# Altium schematic sheet Connector.SchDoc(Connector)
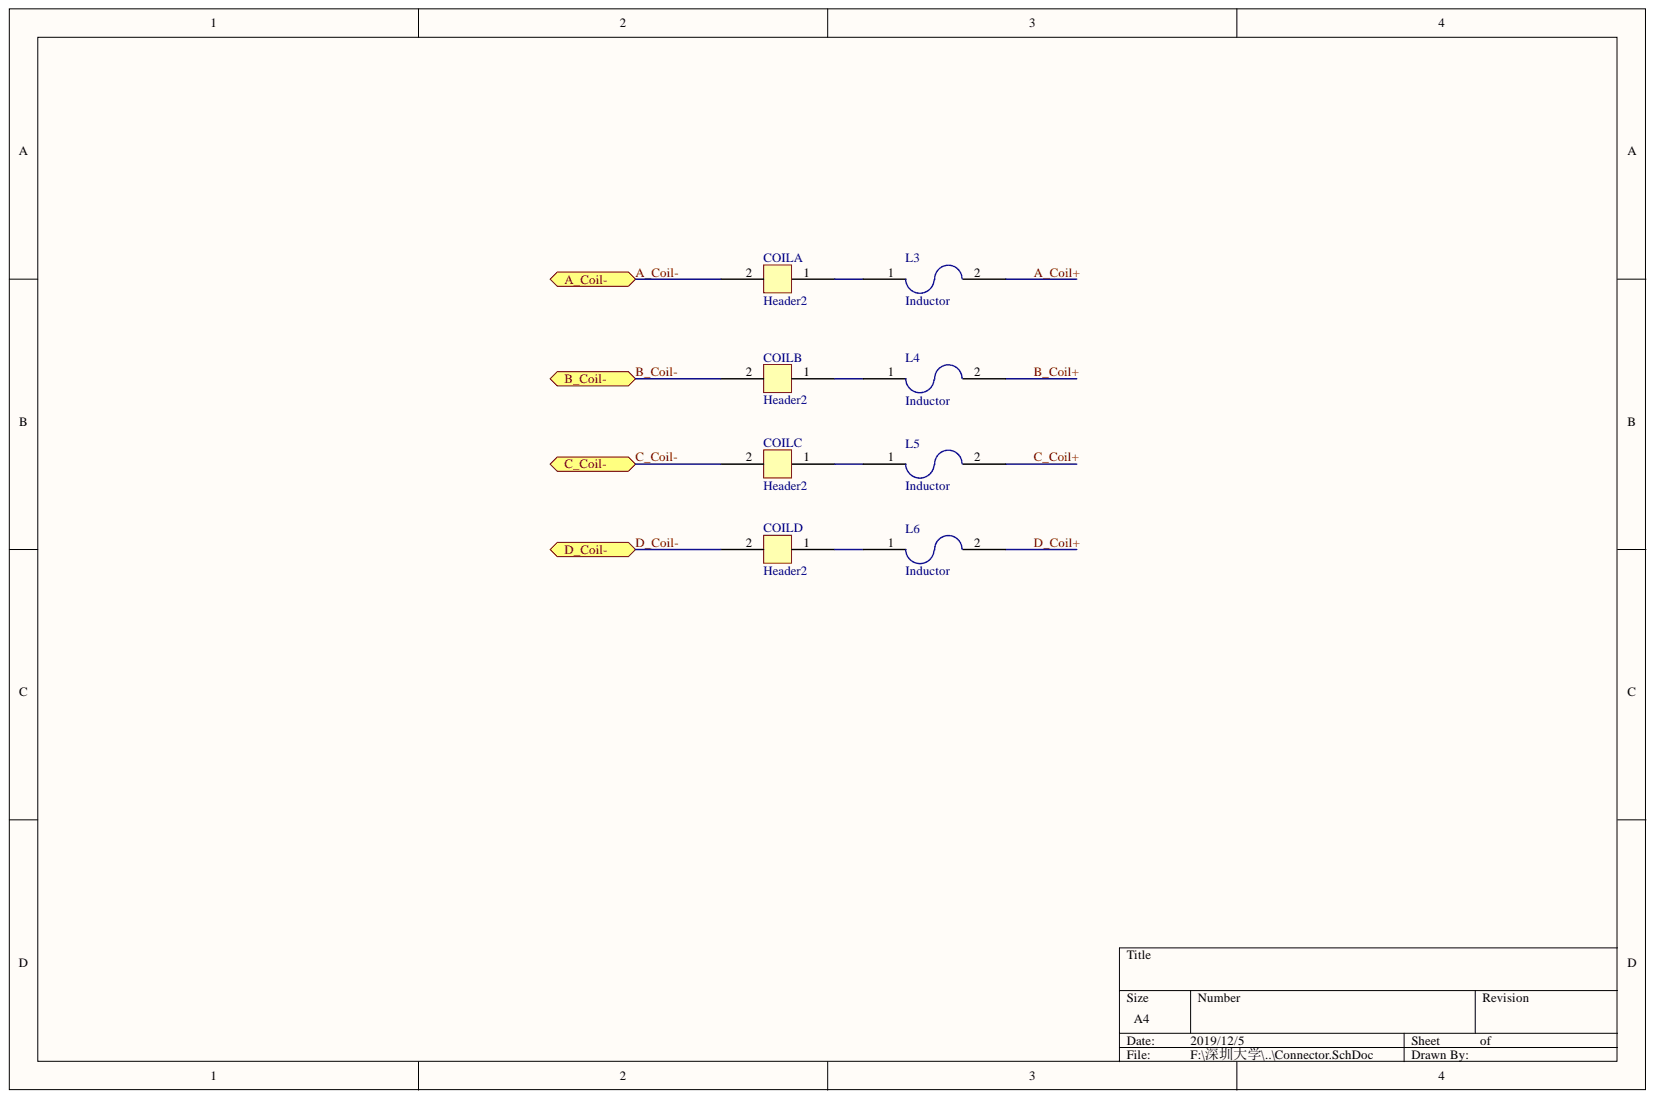
[diagram p0: Connector.SchDoc]

## Parts
COILA  Header2  [XT60PW_M]
COILB  Header2  [XT60PW_M]
COILC  Header2  [XT60PW_M]
COILD  Header2  [XT60PW_M]
L3  Inductor  [53*27mm Inductor]
L4  Inductor  [53*27mm Inductor]
L5  Inductor  [53*27mm Inductor]
L6  Inductor  [53*27mm Inductor]

## Ports
A_Coil-, B_Coil-, C_Coil-, D_Coil-

## Nets
A_Coil+: L3.2
A_Coil-: COILA.2 ; port A_Coil-
B_Coil+: L4.2
B_Coil-: COILB.2 ; port B_Coil-
C_Coil+: L5.2
C_Coil-: COILC.2 ; port C_Coil-
D_Coil+: L6.2
D_Coil-: COILD.2 ; port D_Coil-
NetCOILA_1: COILA.1 L3.1
NetCOILB_1: COILB.1 L4.1
NetCOILC_1: COILC.1 L5.1
NetCOILD_1: COILD.1 L6.1

## Library data of the parts
COILA COILB COILC COILD = Header 2: Component Kind: Standard; Library Name: MPLM_Driver_V10A1.IntLib
L3-L6 = Coil_Inductor: Component Kind: Standard; Library Name: MPLM_Driver_V10A1.IntLib
